# Altium schematic sheet JLI2555 Aplification Board.SchDoc
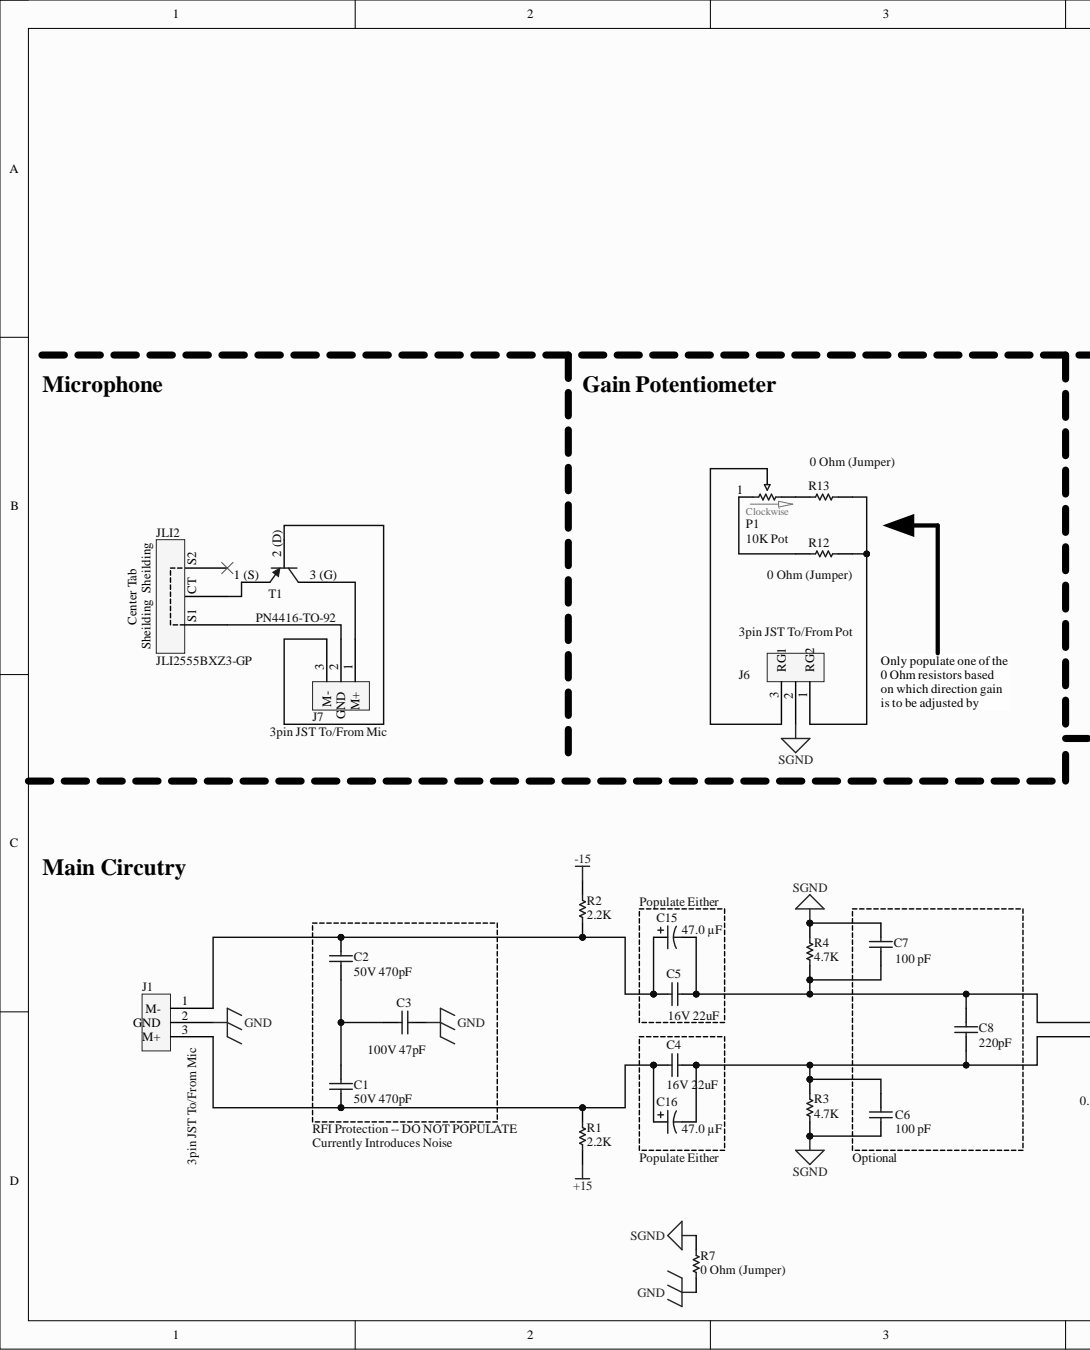
[diagram p0: JLI2555 Aplification Board.SchDoc, left]
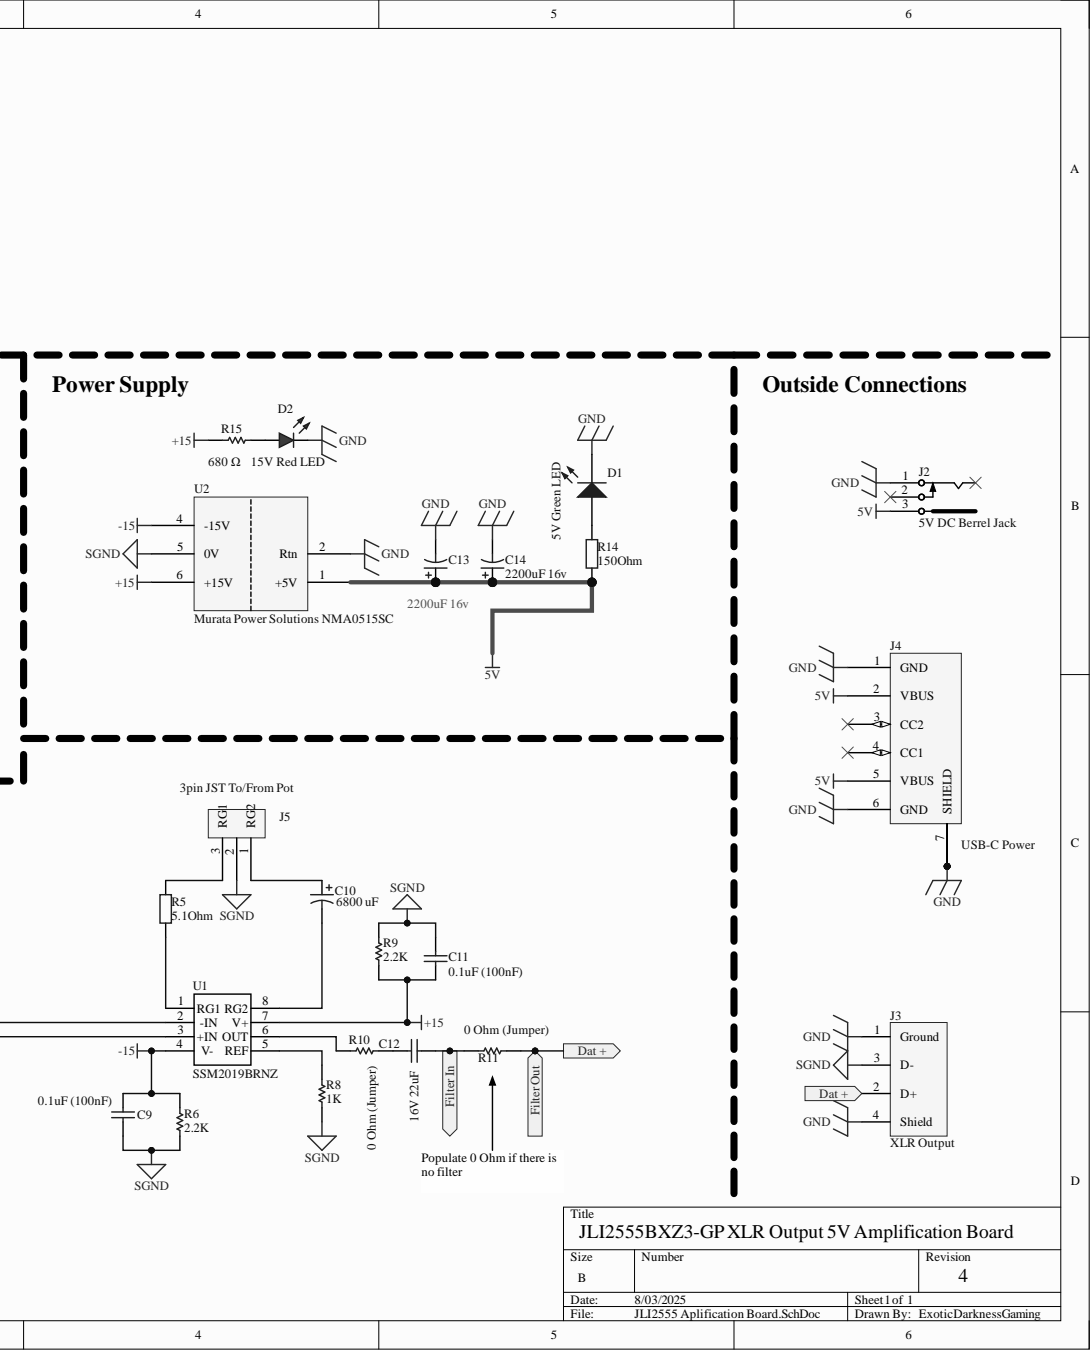
[diagram p1: JLI2555 Aplification Board.SchDoc, right]

## Parts
C1  50V 470pF  [CAPC1608X90X35NL15T15]
C2  50V 470pF  [CAPC1608X90X35NL15T15]
C3  100V 47pF  [CAPC1608X95X40ML15T15]
C4  16V 22uF  [CAPC1608X90X35NL15T15]
C5  16V 22uF  [CAPC1608X90X35NL15T15]
C6  value 100 pF  [PCB-muir31xvgkeow0wifsxe-2]  (hidden comment: Samsung CL10C101JB8NNNC)
C7  value 100 pF  [PCB-muir31xvgkeow0wifsxe-2]  (hidden comment: Samsung CL10C101JB8NNNC)
C8  220pF  [CAPC1608X95X40NL15T15]
C9  0.1uF (100nF)  [PCB-0002-01016-1]
C10  Capacitance: 6800 uF  [PCB-8jj6q1b4c61sx6m5pn06-1]  (hidden comment: United Chemi-Con EGPA250ELL682MM40S)
C11  0.1uF (100nF)  [PCB-0002-01016-1]
C12  16V 22uF  [CAPC1608X90X35NL15T15]
C13  2200uF 16v  [PCB-p62cjentmluym3r5ser3-1]
C14  2200uF 16v  [PCB-p62cjentmluym3r5ser3-1]
C15  Capacitance: 47.0 µF  [PCB-c7y65j60j6t3epqumiv1-3]  (hidden comment: Panasonic EEE-FK1J470P)
C16  Capacitance: 47.0 µF  [PCB-c7y65j60j6t3epqumiv1-3]  (hidden comment: Panasonic EEE-FK1J470P)
D1  5V Green LED  Color: Green  [Lite-On LTST-C170KRKT-Symbol-1]
D2  15V Red LED  Color: Red  [PCB-j4n23iwtpnxz235ppza8-1]
J1  3pin JST To/From Mic  [PCB-2m3c2v1jj2ptkwpcb0tn-3]
J2  5V DC Berrel Jack  [PCB-gxnxm3n04w37egsept5y-1]
J3  XLR Output  [PCB-8hvkguqj1o7d1qh51e3y-1]
J4  USB-C Power  [Molex_217175-0001-Footprint-1]
J5  3pin JST To/From Pot  [PCB-2m3c2v1jj2ptkwpcb0tn-3]
J6  3pin JST To/From Pot  [PCB-2m3c2v1jj2ptkwpcb0tn-3]
J7  3pin JST To/From Mic
JLI2  JLI2555BXZ3-GP
P1  10K Pot  [PCB-aby9f15jtaaeo8gv5iye-1]
R1  2.2K  [PCB-0002-00066-3]
R2  2.2K  [PCB-0002-00066-3]
R3  4.7K  [PCB-m97qmgqrmz272q4klzpu-1]
R4  4.7K  [PCB-m97qmgqrmz272q4klzpu-1]
R5  5.1Ohm  [0603R_A]
R6  2.2K  [PCB-0002-00066-3]
R7  0 Ohm (Jumper)  value 0 Ohm  [PCB-uhg2xw1ikuf8q3tt2tv6-1]
R8  1K  [RESC1608X55X30LL15T15]
R9  2.2K  [PCB-0002-00066-3]
R10  0 Ohm (Jumper)  value 0 Ohm  [PCB-uhg2xw1ikuf8q3tt2tv6-1]
R11  0 Ohm (Jumper)  value 0 Ohm  [PCB-uhg2xw1ikuf8q3tt2tv6-1]
R12  0 Ohm (Jumper)  value 0 Ohm  [PCB-uhg2xw1ikuf8q3tt2tv6-1]
R13  0 Ohm (Jumper)  value 0 Ohm  [PCB-uhg2xw1ikuf8q3tt2tv6-1]
R14  150Ohm  [CAPC1608X90X35NL15T15]
R15  Resistance: 680 Ω  [R0603]  (hidden comment: TE Connectivity CRGCQ0603J680R)
T1  PN4416-TO-92
U1  SSM2019BRNZ  [THAT Corporation THAT1512S08-U-Footprint-1]
U2  Murata Power Solutions NMA0515SC  Manufacturer Part Number: NMA0515SC  [PCB-lzz6r5y7mj74n0u8dniq-1]

## Ports
Dat +, Filter In, Filter Out

## Nets
5V: C13.1 C14.1 J2.3 J4.2(VBUS) J4.5(VBUS) R14.2 U2.1(+5V)
+15: C11.2 R1.1 R9.2 R15.1 U1.7(V+) U2.6(+15V)
-15: C9.2 R2.2 R6.2 U1.4(V-) U2.4(-15V)
GND: C3.2 C13.2 C14.2 D1.2 D2.2 J1.2 J2.1 J3.1(Ground) J3.4(Shield) J4.1(GND) J4.6(GND) J4.7(SHIELD) J4.8 J4.9 J4.10 R7.1 U2.2(Rtn)
SGND: C6.1 C7.2 C9.1 C11.1 J3.3(D-) J5.2 J6.2 R3.1 R4.2 R6.1 R7.2 R8.2 R9.1 U2.5(0V)
Dat +: J3.2(D+) R11.1 ; port Dat +, port Filter Out
Filter In: C12.1 R11.2 ; port Filter In
NetC1_1: C1.1 C4.1 C16.1 J1.3 R1.2
NetC1_2: C1.2 C2.1 C3.1
NetC2_2: C2.2 C5.1 C15.1 J1.1 R2.1
NetC4_2: C4.2 C6.2 C8.1 C16.2 R3.2 U1.3(+IN)
NetC5_2: C5.2 C7.1 C8.2 C15.2 R4.1 U1.2(-IN)
NetC10_1: C10.1 J5.1
NetC10_2: C10.2 U1.8(RG2)
NetC12_2: C12.2 R10.1
NetD1_1: D1.1 R14.1
NetD2_1: D2.1 R15.2
NetJ5_3: J5.3 R5.2
NetJ6_1: J6.1 R12.1 R13.1
NetJ6_3: J6.3 P1.2
NetJ7_1: J7.1 T1.3 (G)
NetJ7_2: J7.2 JLI2.S1
NetJ7_3: J7.3 T1.2 (D)
NetJLI2_CT: JLI2.CT T1.1 (S)
NetP1_1: P1.1 R12.2
NetP1_3: P1.3 R13.2
NetR5_1: R5.1 U1.1(RG1)
NetR8_1: R8.1 U1.5(REF)
NetR10_2: R10.2 U1.6(OUT)

Not connected: J2 2 5 6; J4 3(CC2) 4(CC1); JLI2 S2

## Library data of the parts
C1 = CMP-8f8d4428e931504a-1: Multilayer Ceramic Capacitor, 470 Pf, 5%, C0G / Np0, 50 V, 0603 [1608 Metric] Rohs Compliant: Yes - 0603N471J500CT; Lead-Free Status: Lead Free; Voltage Rating (DC): 50.0 V; Capacitance: 470 pF; Capacitance Tolerance: ±5%; Case/Package: 0603; Component Kind: Standard; Dielectric Characteristic: C0G/NP0; Library Name: My Personal Space; Manufacturer Part Number: 0603N471J500CT; Mounting Style: Surface Mount; Octopart Url: https://octopart.com/0603n471j500ct-walsin+technologies-45040602; OctopartID: 8f8d4428e931504a; Operating Temperature: -55.0 °C (min); Packaging: Cut Tape (CT), Tape & Reel (TR); RoHS: Compliant
C2 = CMP-8f8d4428e931504a-1: Multilayer Ceramic Capacitor, 470 Pf, 5%, C0G / Np0, 50 V, 0603 [1608 Metric] Rohs Compliant: Yes -- 0603N471J500CT; Lead-Free Status: Lead Free; Voltage Rating (DC): 50.0 V; Capacitance: 470 pF; Capacitance Tolerance: ±5%; Case/Package: 0603; Component Kind: Standard; Dielectric Characteristic: C0G/NP0; Library Name: My Personal Space; Manufacturer Part Number: 0603N471J500CT; Mounting Style: Surface Mount; Octopart Url: https://octopart.com/0603n471j500ct-walsin+technologies-45040602; OctopartID: 8f8d4428e931504a; Operating Temperature: -55.0 °C (min); Packaging: Cut Tape (CT), Tape & Reel (TR); RoHS: Compliant
C3 = CMP-3553623-2: Multilayer Ceramic Capacitors MLCC - SMD/SMT 0603 47pF 100volts C0G 5% -- Vitramon VJ0603A470JXBCW1BC; Capacitance: 4.7e-11 F; Dielectric Characteristic: C0G/NP0; Dielectric Material: Tantalum; Lead-Free Status: Lead Free; Voltage Rating (DC): 100.0 V; Capacitance Tolerance: ±5%; Case/Package: 0603; Component Kind: Standard; Library Name: My Personal Space; Manufacturer Part Number: VJ0603A470JXBCW1BC; Octopart Url: https://octopart.com/vj0603a470jxbcw1bc-vishay-44635466; OctopartID: 5b8b90286ba7844c; RoHS: Compliant
C4 C5 C12 = CMP-d07b1d99a8470fbc-2: Cap Ceramic 22uF 16V X5R 20% Pad SMD 0603 85C T/R -- CL10A226MO7JZNC; Manufacturer: Samsung; Description / Value: 16V 22uF X5R ±20% 0603 Multilayer Ceramic Capacitors MLCC - SMD/SMT ROHS; Package/Footprint: 0603; Component Kind: Standard; Library Name: My Personal Space; Mfg Part #: CL10A226MO7JZNC; OctopartID: d07b1d99a8470fbc; Type: SMD
C6 C7 = CMP-db40127128780487-3: 100pF Ceramic capacitor SMD-0603 100pF /50V NP0# J +-5%, Samsung, RoHS; Manufacturer: Samsung Electro-Mechanics; Capacitance Tolerance: ±5%; Dielectric Characteristic: C0G/NP0; Lead-Free Status: Lead Free; Voltage Rating (DC): 50.0 V; Case/Package: 0603; Component Kind: Standard; Library Name: My Personal Space; Manufacturer Part Number: CL10C101JB8NNNC; Mounting Style: Surface Mount; Octopart URL: https://octopart.com/cl10c101jb8nnnc-samsung-9299377; OctopartID: ce3a07bd0eed11fd; Operating Temperature: -55.0 °C (min); RoHS: Compliant; Supplier: LCSC; Supplier Part Number: C14858
C8 = CMP-3551807-2: Multilayer Ceramic Capacitors MLCC - SMD/SMT 0603 220pF 50volts C0G 1% -- Vitramon VJ0603A221FXACW1BC; Capacitance: 2.2e-10 F; Dielectric Characteristic: C0G/NP0; Dielectric Material: Tantalum; Lead-Free Status: Lead Free; Voltage Rating (DC): 50.0 V; Capacitance Tolerance: ±1%; Case/Package: 0603; Component Kind: Standard; Library Name: My Personal Space; Manufacturer Part Number: VJ0603A221FXACW1BC; Octopart Url: https://octopart.com/vj0603a221fxacw1bc-vishay-42804081; OctopartID: e10c2a8be71b3ecb; RoHS: Compliant
C9 C11 = CMP-c41a0d2b65641bdd-6: CAP CER 0.1UF 25V X7R 0603 -- Samsung CL10B104KA8NNNC; Component Kind: Standard; Library Name: My Personal Space
C10 = CMP-15f8dd80d775ca3f-4: Capacitor, Alu Elec, 6800uf, 25v, Radial; Equivalent Series Resistance (ESR): 16.0 mΩ; Lead-Free Status: Lead Free; Voltage Rating (DC): 25.0 V; Component Kind: Standard; Library Name: My Personal Space; Manufacturer Part Number: EGPA250ELL682MM40S; Mounting Style: Through Hole; Octopart URL: http://octopart.com/egpa250ell682mm40s-united+chemi-con-24307691; OctopartID: 15f8dd80d775ca3f; Packaging: Bulk; RoHS: Compliant; Size-Diameter: 18.0 mm; Size-Height: 40.0 mm
C13 C14 = CMP-74debd1cf1b0ab19-1: Cap Aluminum 2200uF 16V 20% (12.5 X 20mm) Radial 5mm 2600mA 10000 hr 105°C Bulk -- Panasonic EEUFR1C222; Capacitance: 2.20 mF; Dielectric Material: Aluminum Electrolytic; Lead-Free Status: Lead Free; Voltage Rating (DC): 16.0 V; Capacitance Tolerance: ±20%; Component Kind: Standard; Library Name: My Personal Space; Lifecycle Status: Not Listed by Manufacturer; Manufacturer Part Number: EEUFR1C222; Mounting Style: Through Hole; Number of Pins: 2; Octopart URL: https://octopart.com/eeufr1c222-panasonic-13148168; OctopartID: 74debd1cf1b0ab19; Operating Temperature: -40.0 °C (min); REACH SVHC Compliance: No SVHC; RoHS: Compliant; Size-Diameter: 12.5 mm; Size-Height: 20.0 mm
C15 C16 = CMP-f38438d4b8202c43-1: Capacitor Aluminum 47uF 63VDC 20% 8 X 10.2mm SMD 250mA 2000 hr T/R; Dielectric Material: Aluminum Electrolytic; Lead-Free Status: Lead Free; Voltage Rating (DC): 63.0 V; Capacitance Tolerance: ±20%; Component Kind: Standard; Library Name: My Personal Space; Lifecycle Status: Not Listed by Manufacturer; Manufacturer Part Number: EEE-FK1J470P; Mounting Style: Surface Mount; Number of Pins: 2; Octopart URL: https://octopart.com/eee-fk1j470p-panasonic-39481026; OctopartID: f38438d4b8202c43; Operating Temperature: 105 °C (max); Packaging: Cut Tape (CT), Tape & Reel (TR); REACH SVHC Compliance: No SVHC; RoHS: Compliant; Size-Diameter: 8.00 mm; Size-Height: 10.2 mm; Size-Length: 10.2 mm; Size-Width: 10.0 mm
D1 = CMP-4cca85c80a58930d-22: LED Uni-Color Green 574nm 2-Pin Chip LED T/R -- Led Green Diode 2512; Manufacturer Part Number: LTST-C170KGKT; Case/Package (SI): 2012; Forward Current: 20.0 mA; Forward Voltage: 2.00 V; Lead-Free Status: Lead Free; Lens Type: Non-Diffused; Luminous Intensity: 35.0 mcd; Viewing Angle: 130 °; Case/Package: 0805; Component Kind: Standard; Library Name: My Personal Space; Mounting Style: Surface Mount, Vertical; Octopart URL: https://octopart.com/ltst-c170kgkt-lite-on-549876; OctopartID: 4cca85c80a58930d; Operating Temperature: 85.0 °C (max); Packaging: Reel; RoHS: Compliant; Size-Height: 1.10 mm; Size-Length: 2.00 mm; Size-Width: 1.25 mm; Wavelength: 574 nm
D2 = CMP-50699963429b8fae-5: Red 3.2 x 1.6 mm 1206 160° Water Clear 6 mcd 2.2 V PCB Surface Mount LED -- Lumex SML-LX1206IC-TR; Case/Package (SI): 3216; Current Rating: 25.0 mA; Forward Voltage: 2.00 V; Lead-Free Status: Lead Free; Lens Type: Non-Diffused; Luminous Intensity: 6.00 mcd; Peak Wavelength: 635 nm; Case/Package: 1206; Component Kind: Standard; Library Name: My Personal Space; Manufacturer Part Number: SML-LX1206IC-TR; Mounting Style: Surface Mount, Vertical; Number of Pins: 2; Octopart URL: http://octopart.com/sml-lx1206ic-tr-lumex-14228; OctopartID: 50699963429b8fae; Packaging: Cut Tape (CT), Tape & Reel (TR); RoHS: Compliant; Size-Height: 1.10 mm; Size-Length: 3.20 mm; Size-Width: 1.60 mm; Viewing Angle: 160 °; Voltage Rating (DC): 2.00 V; Wavelength: 635 nm
J1 J5 J6 = CMP-08bdc1c6665cd77a-5: Wire-To-Board Connector, Xh Series, Surface Mount, Header, 3, 2.5 Mm, Tin Plated Contacts Rohs Compliant: Yes -- JST S3B-XH-SM4-TB(LF)(SN); Gender: Male; Lead-Free Status: Lead Free; Number of Contacts: 3; Component Kind: Standard; Contact Material: Brass; Contact Plating: Tin; Library Name: My Personal Space; Manufacturer Part Number: S3B-XH-SM4-TB(LF)(SN); Mounting Style: Surface Mount; Octopart URL: https://octopart.com/s3b-xh-sm4-tb%28lf%29%28sn%29-jst-7424903; OctopartID: 08bdc1c6665cd77a; Packaging: Cut Tape (CT), Reel, Tape & Reel (TR); REACH SVHC Compliance: No SVHC; RoHS: Compliant
J2 = CMP-da391331d91005fe-25: Conn; AV; Power; Jack; Mini; 2.0mmPinDia; RtAngle; Thru-hole; forS760, S765Plug; Manufacturer Part Number: RAPC722X; Current Rating: 5.0 A; Gender: Female; Lead-Free Status: Lead Free; Mounting Angle: 90.0 °; Number of Contacts: 24; Voltage Rating (AC): 250.0 V; Color: Black; Component Kind: Standard; Contact Plating: Nickel; Library Name: My Personal Space; Mounting Style: Through Hole, PCB, Solder; Octopart URL: http://octopart.com/rapc722x-switchcraft-140879; OctopartID: da391331d91005fe; Packaging: Bulk; REACH SVHC Compliance: No SVHC; RoHS: Compliant
J3 = CMP-0a19c37ff4f2d5de-4: Xlr Audio Connector, Socket, 3, Pcb Mount, Metal Body, Gold Plated Contacts Rohs Compliant: Yes -- Neutrik NC3FAAH2; Contact Resistance: 8.00 mΩ; Current Rating: 6.00 A; Gender: Female; Number of Contacts: 3; Number of Mating Cycles: 1000; Voltage Rating (AC): 50.0 V; Color: Black; Component Kind: Standard; Contact Material: Bronze; Contact Plating: Gold; Flammability Rating: UL 94 HB; Library Name: My Personal Space; Manufacturer Part Number: NC3FAAH2; Material: Plastic; Mounting Style: Through Hole, PC Board, PCB; Octopart URL: https://octopart.com/nc3faah2-neutrik-7134093; OctopartID: 0a19c37ff4f2d5de; Operating Temperature: 80.0 °C (max); Orientation: Right Angle; REACH SVHC Compliance: No SVHC; RoHS: Compliant
J4 = CMP-b08d7f7b4b176f4a-27: Connector USB Type C Female 6Positions 0.5mm Right Angle SMT Embossed T/R - Molex; Manufacturers Part Number: 217175-0001; Component Kind: Standard; ComponentLink1Description: MFG URL; ComponentLink1URL: https://www.molex.com/molex/products/part-detail/io_connectors/2171750001#; Library Name: My Personal Space; Manufacturer: Molex; OctopartID: b08d7f7b4b176f4a
J7 = CMP-4fzrj4y1oa7lnno3ys5p-1: 3 pin genaric JST male connector for all your connector needs when a wire is connected to a circut and then to a PCB on opposite ends. Plugs into a 3 pin JST female.; Component Kind: Standard; CustomComponentID: 4fzrj4y1oa7lnno3ys5p; Library Name: My Personal Space
JLI2 = CMP-08ikalocpbncn3hmplwc-4: JLI-2555BXZ3-GP Microphone Symbol. No footprint. Just a Symbol.; Component Kind: Standard; ComponentLink1Description: Part; ComponentLink1URL: https://www.jlielectronics.com/microphone-capsules/jli-2555bxz3-gp/; ComponentLink2Description: Datasheet; ComponentLink2URL: https://www.jlielectronics.com/content/JLI-2555BXZ3-GP.pdf; CustomComponentID: 08ikalocpbncn3hmplwc; Library Name: My Personal Space; SKU: JLI-2555BXZ3-GP
P1 = CMP-0ebfc7c2e35ed178-3: Res POT 10K Ohm 20% 1/20W 1(Elec)/1(Mech)Turn 6mm (12.1 X 33.5mm) Pin Panel Mount/Bracket Mount Tray -- ALPS RK09L114001T; Lead-Free Status: Lead Free; Component Kind: Standard; Library Name: My Personal Space; Manufacturer Part Number: RK09L114001T; Mounting Style: Panel, Bracket; Octopart URL: http://octopart.com/rk09l114001t-alps-7850119; OctopartID: 0ebfc7c2e35ed178; Packaging: Tray; RoHS: Compliant
R1 R2 R6 R9 = CMP-e2e92e8f14c03d2e-5: Res Thick Film 0603 2.2K Ohm 1% 0.1W(1/10W) ±100ppm/C Pad SMD Automotive T/R; Manufacturer: TE Connectivity; Part Number: CRGCQ0603F2K2; Ex Price: 0.1; VN Price: 40; Voltage/Wattage: 100mW; Component Kind: Standard; Ex Supplier: https://www.mouser.vn/ProductDetail/TE-Connectivity-Holsworthy/CRGCQ0603F2K2?qs=%2Fha2pyFaduh90cFjm7W7TGNAuZ6RJbDKR6paI4%2FFF5sXBsqbzojyA9hYGlpfGVJg&utm_source=octopart&utm_medium=aggregator&utm_campaign=279-CRGCQ0603F2K2&utm_content=TE%20Connectivity; Library Name: My Personal Space; OctopartID: e2e92e8f14c03d2e; Package: 0603; Simulation: SIM-zek8h1ke9xn4wol8qzmd-1; Tolerance: 1%; Value: 2.2K Ohm; VN Supplier: https://www.thegioiic.com/products/dien-tro-2-2-kohm-0603-1
R3 R4 = CMP-c2ee0e88368c1d29-1: SMD Chip Resistor, 4.7 kOhm, ± 1%, 100 mW, 0603 [1608 Metric], Thick Film, General Purpose -- TE Connectivity CRGCQ0603F4K7; Manufacturer Part Number: 3-2176339-3; Case/Package (SI): 1608; Power Rating: 100 mW; Resistance: 4.70 kΩ; Temperature Coefficient: -100 ppm/°C to 100 ppm/°C; Voltage Rating (DC): 75.0 V; Case/Package: 1608, 0603; Component Kind: Standard; Library Name: My Personal Space; Lifecycle Status: Not Listed by Manufacturer; Octopart Url: https://octopart.com/3-2176339-3-te+connectivity-91017476; OctopartID: c2ee0e88368c1d29; Packaging: Tape & Reel (TR); Resistance Tolerance: ±1%; RoHS: Compliant
R5 = CMP-3681818-4: Res Thick Film 0603 5.1 Ohm 5% 1/10W -100ppm/°C to 600ppm/°C Molded SMD SMD Punched Carrier T/R -- Panasonic  ECG ERJ-3GEYJ5R1V; Lead-Free Status: Lead Free; Power Rating: 100 mW; Resistance: 5.10 Ω; Voltage Rating (DC): 75.0 V; Case/Package: 0603; Component Kind: Standard; Library Name: My Personal Space; Lifecycle Status: Not Listed by Manufacturer; Manufacturer Part Number: ERJ-3GEYJ5R1V; Mounting Style: Surface Mount; Octopart Url: http://octopart.com/erj-3geyj5r1v-panasonic-55422129; OctopartID: 1408de4f9ddfcbcf; Packaging: Tape & Reel (TR), Cut Tape (CT); REACH SVHC Compliance: No SVHC; Resistance Tolerance: ±5%; RoHS: Compliant; Seller Landing  Url: https://octopart-clicks.com/click/track?country=US&ak=8157f166&sig=093137c&sid=1106&ppid=55422129&vpid=196780400&ct=offers; Size-Height: 450 µm; Size-Length: 1.60 mm; Size-Width: 800 µm
R7 R11-R13 = CMP-01b5c86325fade72-6: CRG Series 0603 0 Ohm 0.1 W ±100 ppm/°C Surface Mount Thick Film Resistor -- TE Connectivity CRG0603ZR; Ex Price: 0.002; Tolerance: 1%; VN Price: 40; Voltage/Wattage: 100mW; Component Kind: Standard; Ex Supplier: https://www.mouser.vn/ProductDetail/TE-Connectivity-Neohm/CRG0603ZR?qs=%2Fha2pyFaduh2PXS%2FDmnNdJJDhmm%252B2eeA1BiJrXaFZFKeTNcxaaJLOw%3D%3D&utm_source=octopart&utm_medium=aggregator&utm_campaign=279-CRG0603ZR&utm_content=TE%20Connectivity; Library Name: My Personal Space; Manufacturer: TE Connectivity; OctopartID: 01b5c86325fade72; Package: 0603; Part Number: CRG0603ZR; VN Supplier: https://www.digikey.com/en/products/detail/te-connectivity-passive-product/1623094-1/3477691?curr=usd&utm_campaign=buynow&utm_medium=aggregator&utm_source=octopart
R8 = CMP-40c98cafb6d79f0e-1: Res Thick Film 0603 1K Ohm 5% 0.1W(1/10W) ±100ppm/C Pad SMD Automotive T/R -- TE Connectivity CRGCQ0603J1K0; Case/Package (SI): 1608; Power Rating: 100 mW; Resistance: 1.00 kΩ; Temperature Coefficient: -100 ppm/°C to 100 ppm/°C; Voltage Rating (DC): 75.0 V; Case/Package: 1608, 0603; Component Kind: Standard; Library Name: My Personal Space; Lifecycle Status: Not Listed by Manufacturer; Manufacturer Part Number: CRGCQ0603J1K0; Octopart Url: https://octopart.com/crgcq0603j1k0-te+connectivity-91018104; OctopartID: 40c98cafb6d79f0e; Packaging: Tape & Reel (TR); Resistance Tolerance: ±5%; RoHS: Compliant
R10 = CMP-01b5c86325fade72-6: CRG Series 0603 0 Ohm 0.1 W ±100 ppm/°C Surface Mount Thick Film Resistor -- TE Connectivity CRG0603ZR; Ex Price: 0.002; Tolerance: 1%; Value: 0 Ohm; VN Price: 40; Voltage/Wattage: 100mW; Component Kind: Standard; Ex Supplier: https://www.mouser.vn/ProductDetail/TE-Connectivity-Neohm/CRG0603ZR?qs=%2Fha2pyFaduh2PXS%2FDmnNdJJDhmm%252B2eeA1BiJrXaFZFKeTNcxaaJLOw%3D%3D&utm_source=octopart&utm_medium=aggregator&utm_campaign=279-CRG0603ZR&utm_content=TE%20Connectivity; Library Name: My Personal Space; Manufacturer: TE Connectivity; OctopartID: 01b5c86325fade72; Package: 0603; Part Number: CRG0603ZR; VN Supplier: https://www.digikey.com/en/products/detail/te-connectivity-passive-product/1623094-1/3477691?curr=usd&utm_campaign=buynow&utm_medium=aggregator&utm_source=octopart
R14 = CMP-eeaa22cc1e24316d-1: Res Pulse Withstand Thick Film 0603 150 Ohm 1% 1/4W ±100ppm/°C Molded Paper T/R -- TE Connectivity CRGP0603F150R; Case/Package (SI): 1608; Power Rating: 250 mW; Resistance: 150 Ω; Temperature Coefficient: -100 ppm/°C to 100 ppm/°C; Voltage Rating (DC): 50.0 V; Case/Package: 1608, 0603; Component Kind: Standard; Library Name: My Personal Space; Lifecycle Status: Not Listed by Manufacturer; Manufacturer Part Number: CRGP0603F150R; Octopart Url: https://octopart.com/crgp0603f150r-te+connectivity-91018728; OctopartID: eeaa22cc1e24316d; Packaging: Tape & Reel (TR); Resistance Tolerance: ±1%; RoHS: Compliant
R15 = CMP-881444727ee657d3-1: SMD Chip Resistor, 680 Ohm, ± 5%, 100 mW, 0603 [1608 Metric], Thick Film, General Purpose; Case/Package (SI): 1608; Power Rating: 100 mW; Temperature Coefficient: -100 ppm/°C to 100 ppm/°C; Voltage Rating (DC): 75.0 V; Case/Package: 1608, 0603; Component Kind: Standard; Library Name: My Personal Space; Lifecycle Status: Not Listed by Manufacturer; Manufacturer Part Number: CRGCQ0603J680R; Octopart Url: https://octopart.com/crgcq0603j680r-te+connectivity-91018132; OctopartID: 881444727ee657d3; Packaging: Tape & Reel (TR); Resistance Tolerance: ±5%; RoHS: Compliant
T1 = CMP-2q8zpqxkzk2853xl8dm9-1: RF Small Signal Field-Effect Transistor, 1-Element, Ultra High Frequency Band, Silicon, N-Channel, Junction FET, TO-92; Component Kind: Standard; ComponentLink1Description: Octopart; ComponentLink1URL: https://octopart.com/pn4416-to-92-linear+systems-84988998; CustomComponentID: 2q8zpqxkzk2853xl8dm9; Library Name: My Personal Space
U1 = CMP-9b4152f8d9664486-1: Audio Control, 5V To 20V, Soic, 8, -40 C Rohs Compliant: Yes -- Analog Devices; Bandwidth: 7.00 MHz; Component Kind: Standard; ComponentLink1Description: Mouser; ComponentLink1URL: https://www.mouser.com/ProductDetail/Analog-Devices/SSM2019BRNZ?qs=WIvQP4zGanh8wuvRYEvtDQ%3D%3D&utm_source=octopart&utm_medium=aggregator&utm_campaign=584-SSM2019BRNZ&utm_content=Analog+Devices+Inc.; Library Name: My Personal Space; Manufacturer Part Number: SSM2019BRNZ; Mounting Style: Surface Mount; Number of Pins: 8; Octopart Url: https://octopart.com/ssm2019brnz-analog+devices-8998909; Operating Temperature: 85.0 °C (max); REACH SVHC Compliance: No SVHC; RoHS: Compliant
U2 = CMP-392ff2d79988e6e8-3: Module DC-DC 5VIN 2-OUT 15V/-15V 0.033A/-0.033A 1W 5-Pin SIP Tube; Input Voltage (DC): 4.50 V to 5.50 V; Isolation Voltage: 1.00 kV; Lead-Free Status: Lead Free; Number of Outputs: 2; Output Current: 33.0 mA; Output Power: 1.00 W (max); Output Voltage: 15.0 V; Power Rating: 1.00 W; Supply Voltage (DC): 5.00 V; Component Kind: Standard; Flammability Rating: UL 94 V-0; Library Name: My Personal Space; Mounting Style: Through Hole; Octopart URL: https://octopart.com/nma0515sc-murata+power+solutions-49448385; OctopartID: 392ff2d79988e6e8; Packaging: Tube; REACH SVHC Compliance: No SVHC; RoHS: Compliant; Size-Height: 10.0 mm; Size-Width: 6.00 mm
